# Altium schematic sheet Slave.SchDoc
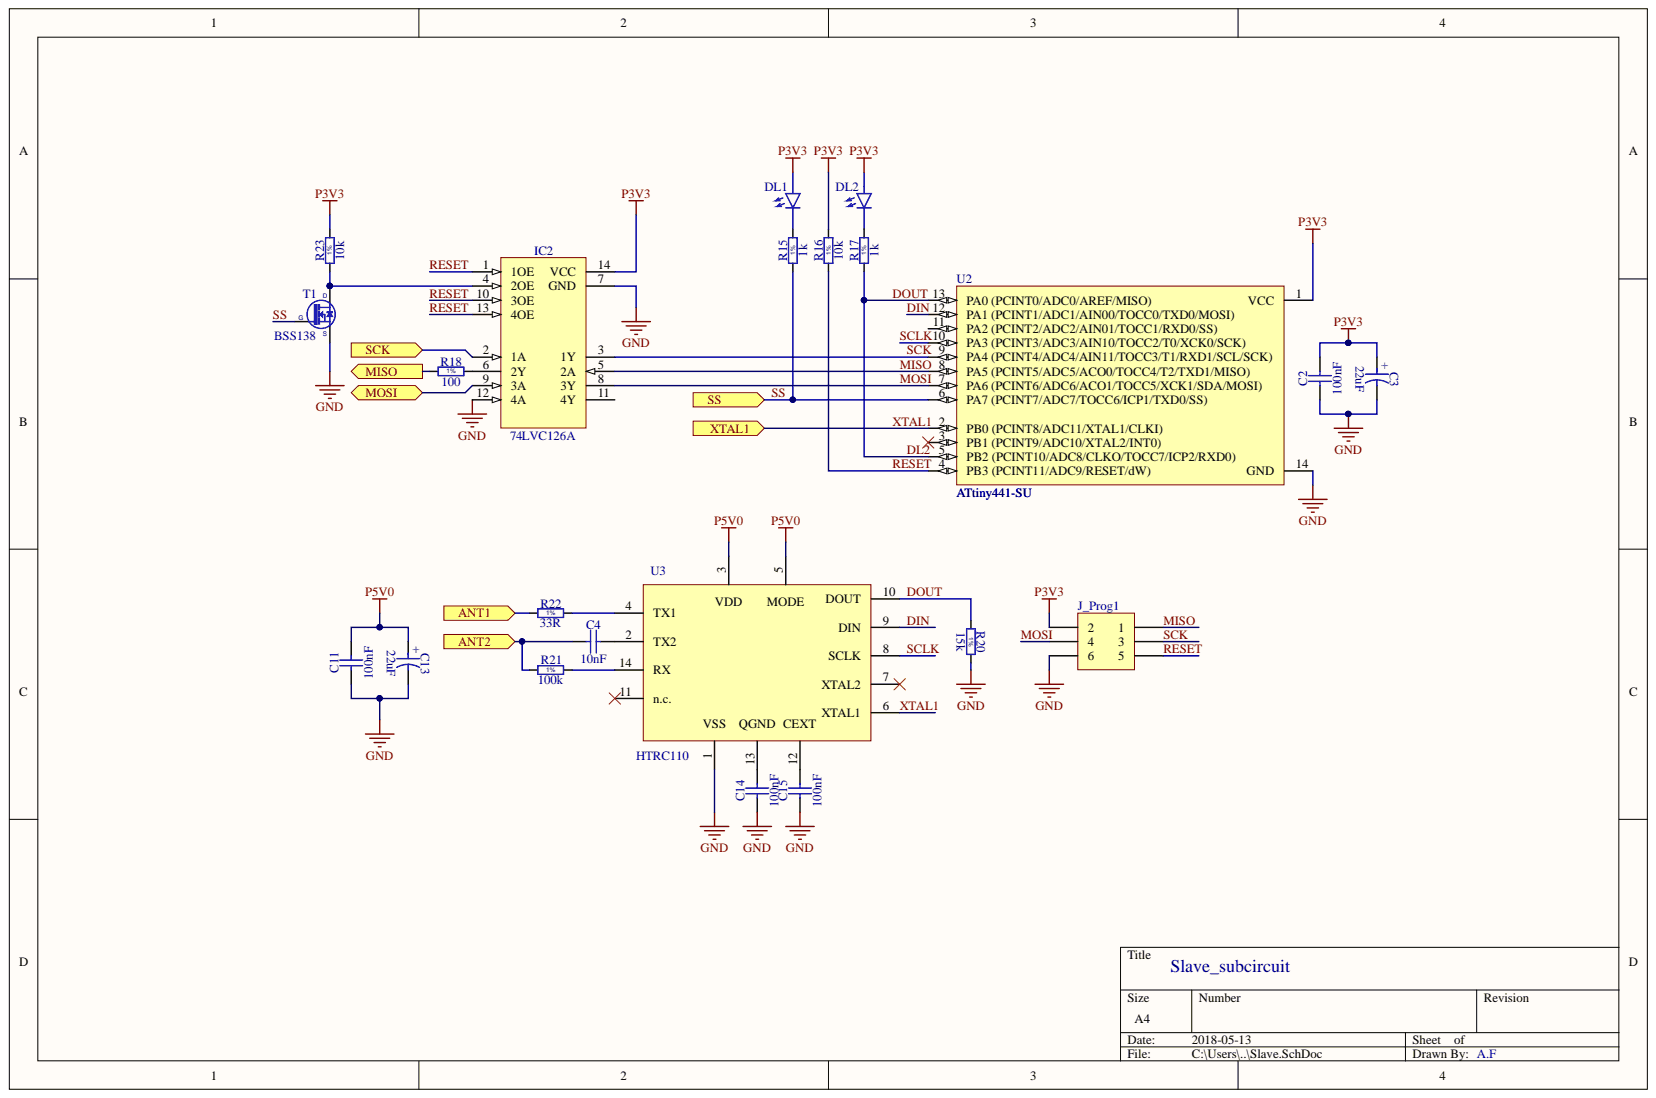
[diagram p0: Slave.SchDoc]

## Parts
C2  100nF  [CAPC1608X87N]
C3  22uF  [CAPAE430X540N]
C4  10nF  [CAPC1608X87N]
C11  100nF  [CAPC1608X87N]
C13  22uF  [CAPAE430X540N]
C14  100nF  [CAPC1608X87N]
C15  100nF  [CAPC1608X87N]
DL1  (no value)  [0805_LED]
DL2  (no value)  [0805_LED]
IC2  74LVC126A  [SOIC127P600X175-14N]
J_Prog1  (no value)  [HDR2X3]
R15  1k  [RESC2012X60N]
R16  10k  value 1k  [RESC2012X60N]
R17  1k  [RESC2012X60N]
R18  100  [RESC1608X55N]
R20  15k  [RESC1608X55N]
R21  100k  [RESC1608X55N]
R22  33R  [RESC1608X55N]
R23  10k  [RESC1608X55N]
T1  BSS138  [SOT95P240X110-3N]
U2  ATtiny441-SU  [14S1_L]
U3  HTRC110  [SOIC127P600X175-14N]

## Ports
ANT1, ANT2, MISO, MOSI, SCK, SS, XTAL1

## Nets
GND: C2.1 C3.2 C11.1 C13.2 C14.1 C15.1 IC2.7(GND) IC2.12(4A) J_Prog1.6 R20.2 T1.2 U2.14(GND) U3.1
P3V3: C2.2 C3.1 DL1.A DL2.A IC2.14(VCC) J_Prog1.2 R16.2 R23.2 U2.1(VCC)
P5V0: C11.2 C13.1 U3.3 U3.5
ANT1: R22.1 ; port ANT1
ANT2: C4.1 R21.1 ; port ANT2
DIN: U2.12(PA1_(PCINT1/ADC1/AIN00/TOCC0/TXD0/MOSI)) U3.9(DIN)
DL2: R17.1 R20.1 U2.5(PB2_(PCINT10/ADC8/CLKO/TOCC7/ICP2/RXD0)) U2.13(PA0_(PCINT0/ADC0/AREF/MISO)) U3.10(DOUT)
MISO: IC2.5(2A) J_Prog1.1 U2.8(PA5_(PCINT5/ADC5/ACO0/TOCC4/T2/TXD1/MISO))
MOSI: IC2.8(3Y) J_Prog1.4 U2.7(PA6_(PCINT6/ADC6/ACO1/TOCC5/XCK1/SDA/MOSI))
RESET: IC2.1(1OE) IC2.10(3OE) IC2.13(4OE) J_Prog1.5 R16.1 U2.4(PB3_(PCINT11/ADC9/RESET/dW))
SCK: IC2.3(1Y) J_Prog1.3 U2.9(PA4_(PCINT4/ADC4/AIN11/TOCC3/T1/RXD1/SCL/SCK))
SCLK: U2.10(PA3_(PCINT3/ADC3/AIN10/TOCC2/T0/XCK0/SCK)) U3.8(SCLK)
SS: R15.1 T1.1 U2.6(PA7_(PCINT7/ADC7/TOCC6/ICP1/TXD0/SS)) ; port SS
XTAL1: U2.2(PB0_(PCINT8/ADC11/XTAL1/CLKI)) U3.6(XTAL1) ; port XTAL1
NetC4_2: C4.2 U3.2(TX2)
NetC14_2: C14.2 U3.13
NetC15_2: C15.2 U3.12
NetDL1_K: DL1.K R15.2
NetDL2_K: DL2.K R17.2
NetIC2_2: IC2.2(1A) ; port SCK
NetIC2_4: IC2.4(2OE) R23.1 T1.3
NetIC2_6: IC2.6(2Y) R18.2
NetIC2_9: IC2.9(3A) ; port MOSI
NetR18_1: R18.1 ; port MISO
NetR21_2: R21.2 U3.14(RX)
NetR22_2: R22.2 U3.4(TX1)

Not connected: IC2 11(4Y); U2 3(PB1_(PCINT9/ADC10/XTAL2/INT0)) 11(PA2_(PCINT2/ADC2/AIN01/TOCC1/RXD0/SS)); U3 7(XTAL2) 11(n.c.)

## Library data of the parts
C2 C14 C15 = CC0603_100NF_50V_10%_X5R: Non-polarised capacitor; Manufacturer: GENERIC; Manufacturer1 Part Number: C1608X5R1H104K080AA; Part Description: SMD Multilayer Chip Ceramic Capacitor; Database Table Name: Capacitors SMD; TC: X5R; Tolerance: ±10%; Voltage: 50V; Case: 0603; Component Kind: Standard; Component Type: Standard; CreateDate: 08.01.2016; HelpURL: \\cern.ch\dfs\Applications\Altium\Datasheets\CC0603_X5R_TDK_C.pdf; LatestRevisionDate: 08.01.2016; Library Name: Capacitors.DbLib; Manufacturer1 ComponentHeight: 0.9mm; Manufacturer1 Example: TDK; Manufacturer Part Number: CC0603_100NF_50V_10%_X5R; Mounted: Yes; Part Number: CC0603_100NF_50V_10%_X5R; Pin Count: 2; Sense: No; SMD: Yes; Socket: No; Status: None; Value: 100nF; Author: CERN DEM MR
C3 C13 = CAE430-540_22UF_10V_20%: Polarised capacitor; Manufacturer: PANASONIC; Manufacturer Part Number: EEEFK1A220AR; Part Description: Aluminum Electrolytic SMT Capacitor; Database Table Name: Capacitors SMD; Tolerance: ±20%; Voltage: 10V; Case: B; ComponentHeight: 5.8mm; Component Kind: Standard; Component Type: Standard; CreateDate: 2007-12-03; HelpURL: \\cern.ch\dfs\Applications\Altium\Datasheets\CAE_PANASONIC_FK.pdf; LatestRevisionDate: 2014-11-05; Library Name: Capacitors.DbLib; Mounted: Yes; Part Number: CAE430-540_22UF_10V_20%; Pin Count: 2; Sense: No; SMD: Yes; Socket: No; Status: Not Recommended; Value: 22uF; Author: CERN DEM JLC
C4 = CC0603_10NF_50V_10%_X7R: Non-polarised capacitor; Manufacturer: GENERIC; Manufacturer1 Part Number: 223858615636; Part Description: SMD Multilayer Chip Ceramic Capacitor; Database Table Name: Capacitors SMD; TC: X7R; Tolerance: ±10%; Voltage: 50V; Case: 0603; Component Kind: Standard; Component Type: Standard; CreateDate: 2007-12-03; HelpURL: \\cern.ch\dfs\Applications\Altium\Datasheets\CC0603_X7R_PHYCOMP.pdf; LatestRevisionDate: 2007-12-03; Library Name: Capacitors.DbLib; Manufacturer1 ComponentHeight: 0.87mm; Manufacturer1 Example: PHYCOMP; Manufacturer Part Number: CC0603_10NF_50V_10%_X7R; Mounted: Yes; Part Number: CC0603_10NF_50V_10%_X7R; Pin Count: 2; Sense: No; SMD: Yes; Socket: No; Status: Preferred; Value: 10nF; Author: CERN DEM JLC
C11 = CC0603_10NF_50V_10%_X7R: Non-polarised capacitor; Manufacturer: GENERIC; Manufacturer1 Part Number: 223858615636; Part Description: SMD Multilayer Chip Ceramic Capacitor; Database Table Name: Capacitors SMD; TC: X7R; Tolerance: ±10%; Value: 10nF; Voltage: 50V; Case: 0603; Component Kind: Standard; Component Type: Standard; CreateDate: 2007-12-03; HelpURL: \\cern.ch\dfs\Applications\Altium\Datasheets\CC0603_X7R_PHYCOMP.pdf; LatestRevisionDate: 2007-12-03; Library Name: Capacitors.DbLib; Manufacturer1 ComponentHeight: 0.87mm; Manufacturer1 Example: PHYCOMP; Manufacturer Part Number: CC0603_10NF_50V_10%_X7R; Mounted: Yes; Part Number: CC0603_10NF_50V_10%_X7R; Pin Count: 2; Sense: No; SMD: Yes; Socket: No; Status: Preferred; Author: CERN DEM JLC
DL1 DL2 = LED1: Light Emitting Diode; Component Kind: Standard; Library Name: Disc-Opto.SchLib
IC2 = (no symbol name): Quadruple Bus Buffer with 3-State Outputs; Manufacturer: TEXAS INSTRUMENTS; Bonding: No; Device: SN74HC125D; PressFit: No; Case: SOIC14; ComponentHeight: 1.75mm; Component Kind: Standard; Component Type: Standard; CreateDate: 2011-06-30; HelpURL: \\cern.ch\dfs\Applications\Altium\Datasheets\SN74HC125D.pdf; LatestRevisionDate: 2011-06-30; Library Name: RFID_system.SCHLIB; Manufacturer Part Number: SN74HC125D; Mounted: Yes; PackageDescription: SOIC 14, Pitch 1.27mm - Body 4x8.75mm, IPC Medium Density; Part Description: Quadruple Bus Buffer with 3-State Outputs; Part Number: SN74HC125D; Pin Count: 14; Sense: No; SMD: Yes; Socket: No; Author: CERN DEM JLC
J_Prog1 = Header 3X2: Header, 3-Pin, Dual row; Component Kind: Standard; LatestRevisionDate: 17-Jul-2002; LatestRevisionNote: Re-released for DXP Platform.; Library Name: Miscellaneous Connectors.IntLib; Signal Integrity: Connector; Publisher: Altium Limited
R15-R17 = R0805_1K_1%_0.33W_100PPM_VISHAY_CRCW-HP: Resistor - 1%; Manufacturer: VISHAY DRALORIC; Manufacturer Part Number: CRCW08051K00FKEAHP; Part Description: 150V, Pulse Proof, High Power Thick Film Chip Resistor; Database Table Name: Resistors SMD; Power: 0.33W; PressFit: No; TC: ±100ppm/°C; Case: 0805; Component Kind: Standard; Component Type: Standard; CreateDate: 2010-06-16; HelpURL: \\cern.ch\dfs\Applications\Altium\Datasheets\R0805_VISHAY_CRCW-HP e3.pdf; LatestRevisionDate: 2010-06-16; Library Name: Resistors.DbLib; Manufacturer1 ComponentHeight: 0.6mm; Mounted: Yes; Part Number: R0805_1K_1%_0.33W_100PPM_VISHAY_CRCW-HP; Pin Count: 2; Sense: No; SMD: Yes; Socket: No; Status: None; Tolerance: ±1%; Author: CERN DEM JLC
  R15 R17: Value: 1k
R18 = R0603_100R_1%_0.1W_100PPM: Resistor - 1%; Manufacturer: GENERIC; Manufacturer1 Part Number: NRC06F4700TRF; Part Description: General Purpose Thick Film Chip Resistor; Database Table Name: Resistors SMD; Power: 0.1W; PressFit: No; TC: ±100ppm/°C; Case: 0603; Component Kind: Standard; Component Type: Standard; CreateDate: 2007-12-03; HelpURL: \\cern.ch\dfs\Applications\Altium\Datasheets\R0603_NIC_NRC.pdf; LatestRevisionDate: 2008-03-13; Library Name: Resistors.DbLib; Manufacturer1 ComponentHeight: 0.55mm; Manufacturer1 Example: NIC COMPONENT; Manufacturer Part Number: R0603_100R_1%_0.1W_100PPM; Mounted: Yes; Part Number: R0603_100R_1%_0.1W_100PPM; Pin Count: 2; Sense: No; SMD: Yes; Socket: No; Status: Preferred; Tolerance: ±1%; Value: 100; Author: CERN DEM JLC
R20 = R0603_15K_1%_0.1W_100PPM: Resistor - 1%; Manufacturer: GENERIC; Manufacturer1 Part Number: NRC06F1502TRF; Part Description: General Purpose Thick Film Chip Resistor; Database Table Name: Resistors SMD; Power: 0.1W; PressFit: No; TC: ±100ppm/°C; Case: 0603; Component Kind: Standard; Component Type: Standard; CreateDate: 2015-08-17; HelpURL: \\cern.ch\dfs\Applications\Altium\Datasheets\R0603_NIC_NRC.pdf; LatestRevisionDate: 2015-08-17; Library Name: Resistors.DbLib; Manufacturer1 ComponentHeight: 0.55mm; Manufacturer1 Example: NIC COMPONENT; Manufacturer Part Number: R0603_15K_1%_0.1W_100PPM; Mounted: Yes; Part Number: R0603_15K_1%_0.1W_100PPM; Pin Count: 2; Sense: No; SMD: Yes; Socket: No; Status: Preferred; Tolerance: ±1%; Value: 15k; Author: CERN DEM JMW
R21 R22 = R0603_15K_1%_0.1W_100PPM: Resistor - 1%; Manufacturer: GENERIC; Manufacturer1 Part Number: NRC06F1502TRF; Part Description: General Purpose Thick Film Chip Resistor; Database Table Name: Resistors SMD; Power: 0.1W; PressFit: No; TC: ±100ppm/°C; Value: 15k; Case: 0603; Component Kind: Standard; Component Type: Standard; CreateDate: 2015-08-17; HelpURL: \\cern.ch\dfs\Applications\Altium\Datasheets\R0603_NIC_NRC.pdf; LatestRevisionDate: 2015-08-17; Library Name: Resistors.DbLib; Manufacturer1 ComponentHeight: 0.55mm; Manufacturer1 Example: NIC COMPONENT; Manufacturer Part Number: R0603_15K_1%_0.1W_100PPM; Mounted: Yes; Part Number: R0603_15K_1%_0.1W_100PPM; Pin Count: 2; Sense: No; SMD: Yes; Socket: No; Status: Preferred; Tolerance: ±1%; Author: CERN DEM JMW
R23 = R0603_10K_1%_0.1W_100PPM: Resistor - 1%; Manufacturer: GENERIC; Manufacturer1 Part Number: NRC06F1002TRF; Part Description: General Purpose Thick Film Chip Resistor; Database Table Name: Resistors SMD; Power: 0.1W; PressFit: No; TC: ±100ppm/°C; Case: 0603; Component Kind: Standard; Component Type: Standard; CreateDate: 2007-12-03; HelpURL: \\cern.ch\dfs\Applications\Altium\Datasheets\R0603_NIC_NRC.pdf; LatestRevisionDate: 2008-03-13; Library Name: Resistors.DbLib; Manufacturer1 ComponentHeight: 0.55mm; Manufacturer1 Example: NIC COMPONENT; Manufacturer Part Number: R0603_10K_1%_0.1W_100PPM; Mounted: Yes; Part Number: R0603_10K_1%_0.1W_100PPM; Pin Count: 2; Sense: No; SMD: Yes; Socket: No; Status: Preferred; Tolerance: ±1%; Value: 10k; Author: CERN DEM JLC
T1 = BSS138LT1G: N-CHANNEL MOSFET WITH DIODE; Manufacturer: ON SEMICONDUCTOR; Part Description: 50V 200mA N-Channel Enhancement Mode Field-Effect Transistor; Bonding: No; Database Table Name: Transistors; PressFit: No; Case: SOT23-3; ComponentHeight: 1.11mm; Component Kind: Standard; Component Type: Standard; CreateDate: 2009-03-23; Device: BSS138LT1G; HelpURL: \\cern.ch\dfs\Applications\Altium\Datasheets\BSS138LT1G.pdf; LatestRevisionDate: 2009-03-23; Library Name: ICs And Semiconductors.DbLib; Manufacturer Part Number: BSS138LT1G; Mounted: Yes; PackageDescription: SOT23 3-Leads, Pitch 0.95mm - Body 1.4x3mm, IPC Medium Density; Part Number: BSS138LT1G; Pin Count: 3; Sense: No; SMD: Yes; Socket: No; Author: CERN DEM JLC
U2 = ATtiny441-SU: 8-bit AVR Microcontroller, 1.8-5.5V, 2KB Flash, 128 Bytes SRAM, 12 GPIO pins, 14-pin SOIC, Industrial Grade (-40°C to 85°C), Pb-Free; Code_IPC: SOIC127P600-14; Code_JEDEC: MS-012-AB; Component Kind: Standard; ComponentLink1Description: ATtiny20 Datasheet; ComponentLink1URL: http://www.atmel.com/dyn/resources/prod_documents/doc8235.pdf; ComponentLink2Description: ATtiny20 Summary; ComponentLink2URL: http://www.atmel.com/dyn/resources/prod_documents/8235S.pdf; ComponentLink3Description: Atmel.com Home; ComponentLink3URL: http://www.atmel.com; DatasheetVersion: revA, Mar-2010; Library Name: RFID_system_2.SCHLIB; Name: ATtiny441-SU; PackageDescription: 14-Lead, 0.150” Wide Body, Plastic Gull Wing Small Outline Package (SOIC); PackageReference: 14S1; PackageVersion: revA, Feb-2002; Published: 1-Apr-2010; Supplier 1: Digi-Key; Supplier Part Number 1: 1611-ATTINY44-15SSZCT-ND; Publisher: Altium Limited
U3 = HTRC110: HITAG reader chip; Component Kind: Standard; Library Name: Slave2.SCHLIB
